# Altium schematic sheet MCU.SchDoc(MCU)
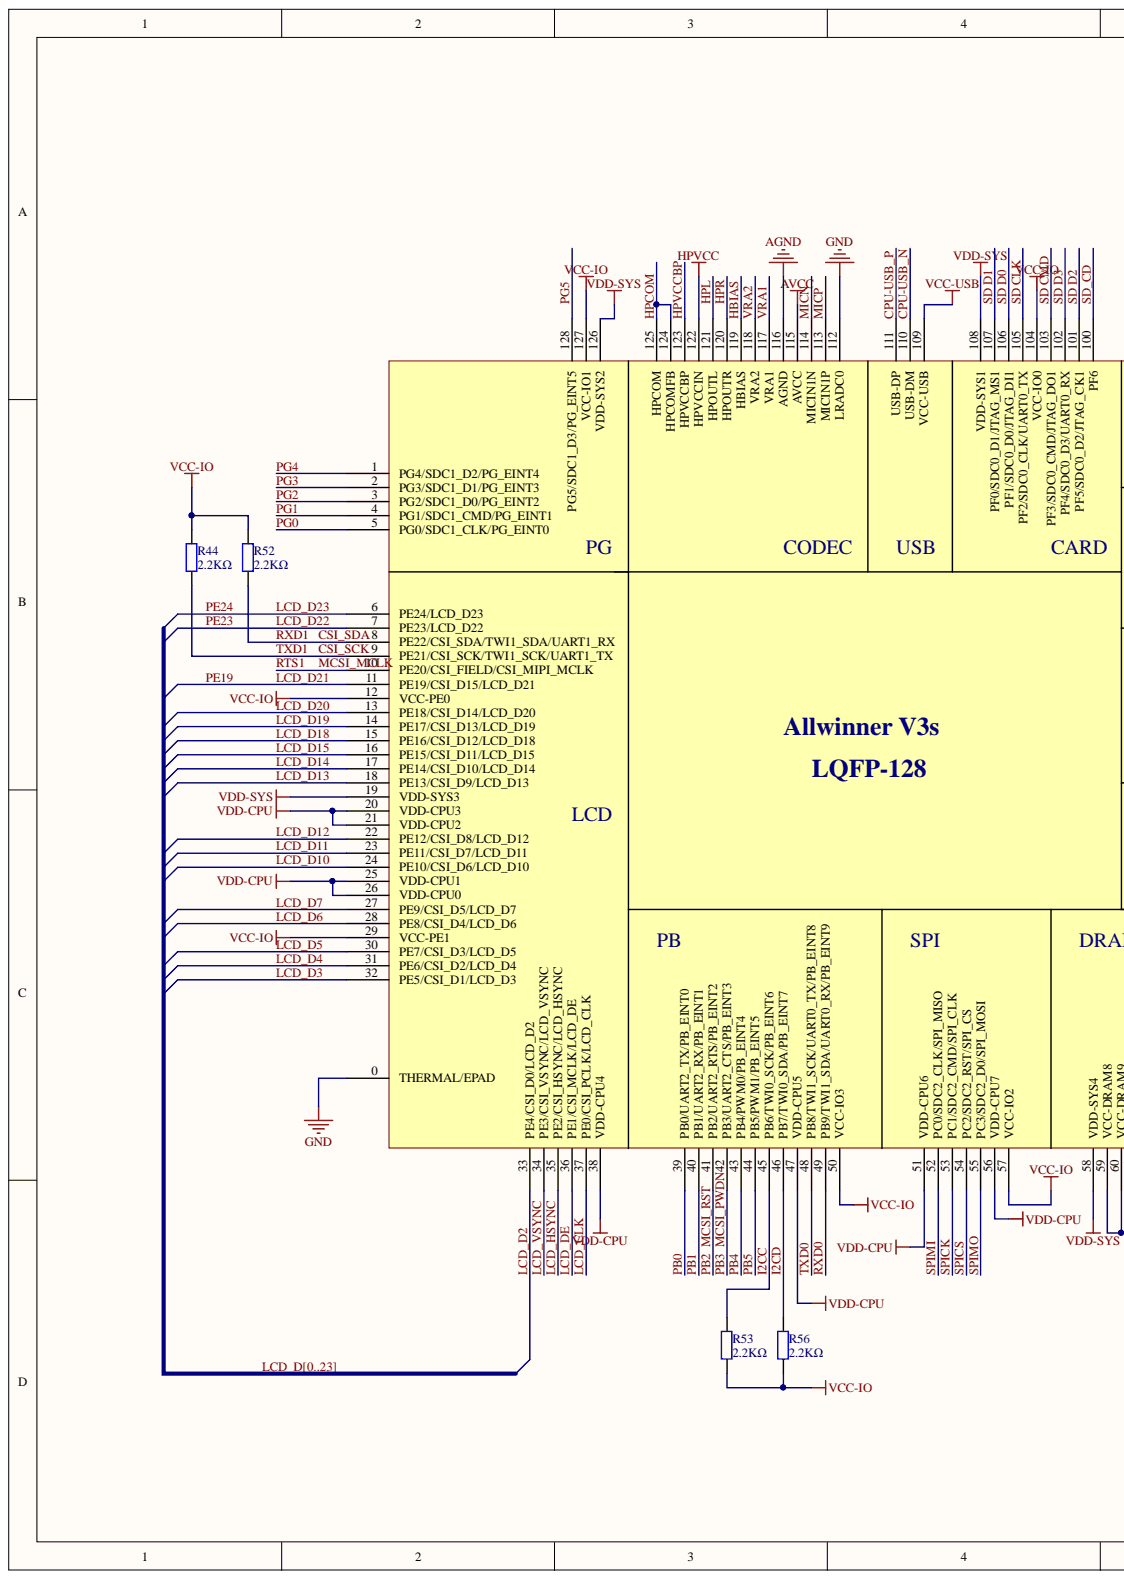
[diagram p0: MCU.SchDoc, left]
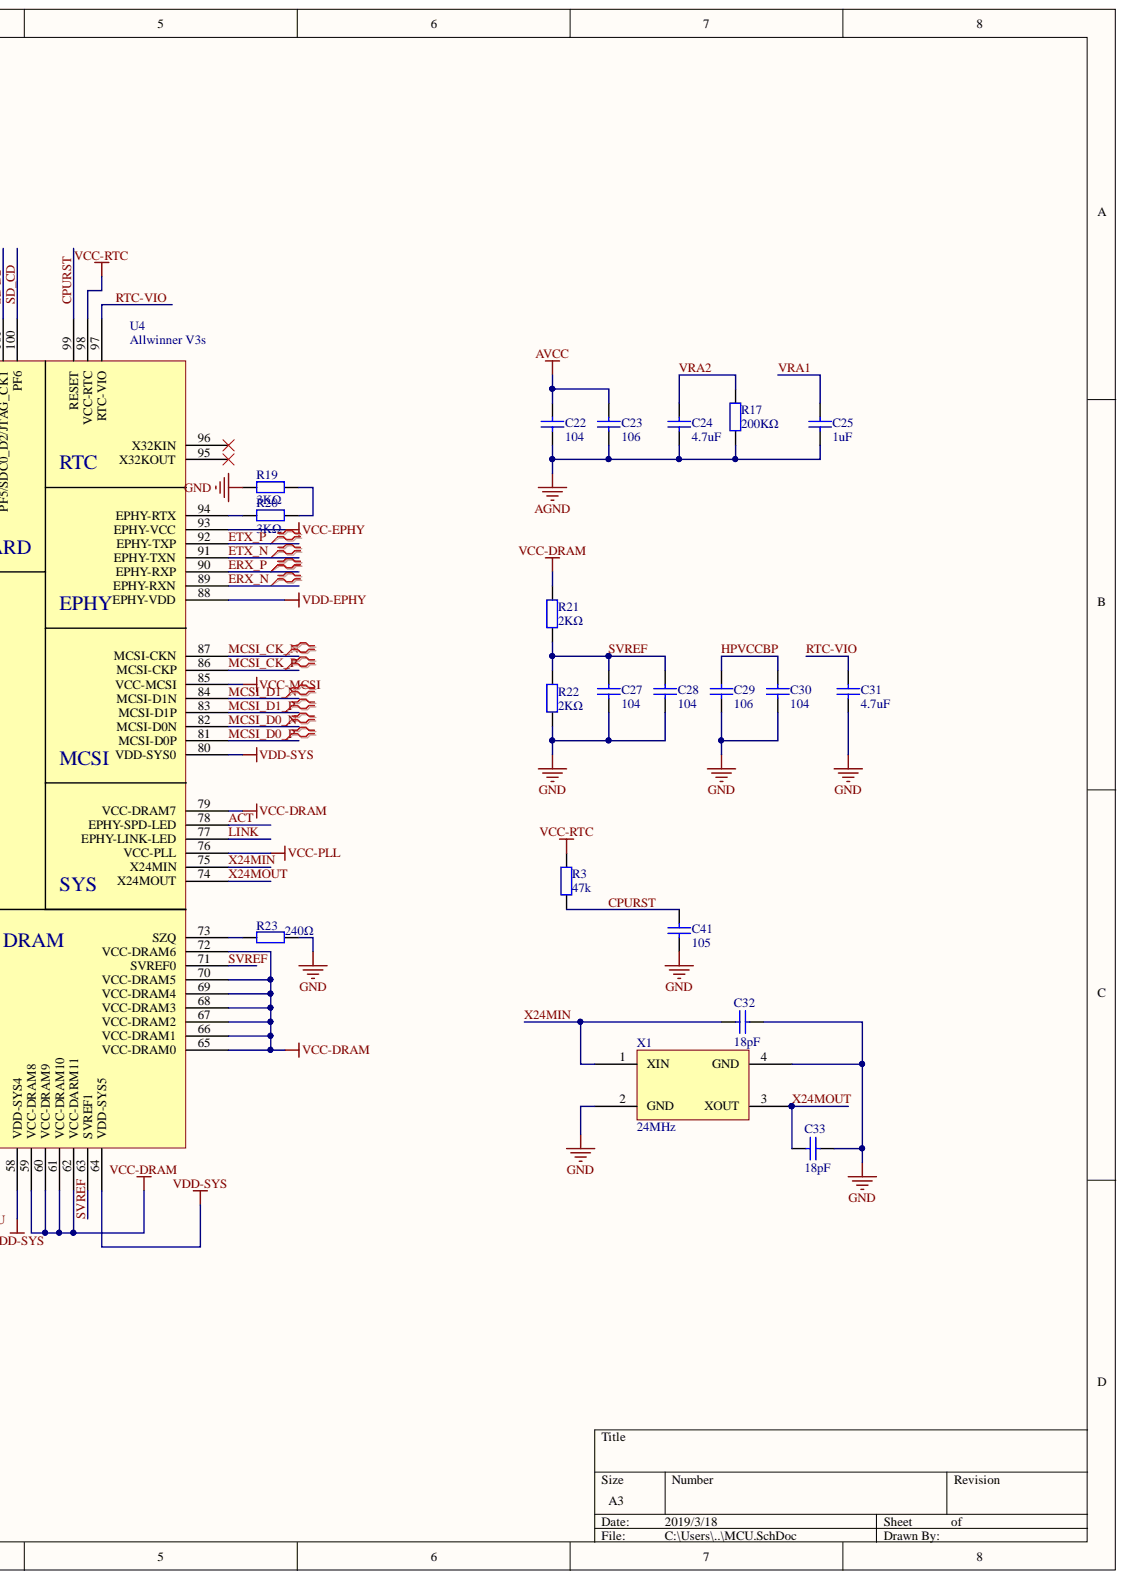
[diagram p1: MCU.SchDoc, right]

## Parts
C22  104  [0402_C]
C23  106  [0402_C]
C24  4.7uF  [0402_C]
C25  1uF  [0402_C]
C27  104  [0402_C]
C28  104  [0402_C]
C29  106  [0402_C]
C30  104  [0402_C]
C31  4.7uF  [0402_C]
C32  18pF  [0402_C]
C33  18pF  [0402_C]
C41  105  [0402_C]
R3  47k  [0402_R]
R17  200KΩ  [0402_R]
R19  3KΩ  [0603_R]
R20  3KΩ  [0603_R]
R21  2KΩ  [0402_R]
R22  2KΩ  [0402_R]
R23  240Ω  [0603_R]
R44  2.2KΩ  [0402_R]
R52  2.2KΩ  [0402_R]
R53  2.2KΩ  [0402_R]
R56  2.2KΩ  [0402_R]
U4  Allwinner V3s  [LQFP-128_THERMAL]
X1  24MHz  [SMD-3225_4P]

## Nets
AGND: C22.1 C23.1 C24.1 C25.1 R17.1 U4.116(AGND)
AVCC: C22.2 C23.2 U4.115(AVCC)
GND: C27.1 C28.1 C29.1 C30.1 C31.1 C32.2 C33.2 C41.1 R19.1 R22.1 R23.1 U4.0(THERMAL/EPAD) U4.112(LRADC0) X1.2(GND) X1.4(GND)
HPVCC: U4.122(HPVCCIN)
VCC-DRAM: R21.2 U4.59(VCC-DRAM8) U4.60(VCC-DRAM9) U4.61(VCC-DRAM10) U4.62(VCC-DARM11) U4.65(VCC-DRAM0) U4.66(VCC-DRAM1) U4.67(VCC-DRAM2) U4.68(VCC-DRAM3) U4.69(VCC-DRAM4) U4.70(VCC-DRAM5) U4.72(VCC-DRAM6) U4.79(VCC-DRAM7)
VCC-EPHY: U4.93(EPHY-VCC)
VCC-IO: R44.2 R52.2 R53.1 R56.1 U4.12(VCC-PE0) U4.29(VCC-PE1) U4.50(VCC-IO3) U4.57(VCC-IO2) U4.104(VCC-IO0) U4.127(VCC-IO1)
VCC-MCSI: U4.85(VCC-MCSI)
VCC-PLL: U4.76(VCC-PLL)
VCC-RTC: R3.2 U4.98(VCC-RTC)
VCC-USB: U4.109(VCC-USB)
VDD-CPU: U4.20(VDD-CPU3) U4.21(VDD-CPU2) U4.25(VDD-CPU1) U4.26(VDD-CPU0) U4.38(VDD-CPU4) U4.47(VDD-CPU5) U4.51(VDD-CPU6) U4.56(VDD-CPU7)
VDD-EPHY: U4.88(EPHY-VDD)
VDD-SYS: U4.19(VDD-SYS3) U4.58(VDD-SYS4) U4.64(VDD-SYS5) U4.80(VDD-SYS0) U4.108(VDD-SYS1) U4.126(VDD-SYS2)
ACT: U4.78(EPHY-SPD-LED)
CPU-USB_N: U4.110(USB-DM)
CPU-USB_P: U4.111(USB-DP)
CPURST: C41.2 R3.1 U4.99(RESET)
CSI_SCK: R44.1 U4.9(PE21/CSI_SCK/TWI1_SCK/UART1_TX)
CSI_SDA: R52.1 U4.8(PE22/CSI_SDA/TWI1_SDA/UART1_RX)
ERX_N: U4.89(EPHY-RXN)
ERX_P: U4.90(EPHY-RXP)
ETX_N: U4.91(EPHY-TXN)
ETX_P: U4.92(EPHY-TXP)
HBIAS: U4.119(HBIAS)
HPCOM: U4.124(HPCOMFB) U4.125(HPCOM)
HPL: U4.121(HPOUTL)
HPR: U4.120(HPOUTR)
HPVCCBP: C29.2 C30.2 U4.123(HPVCCBP)
I2CC: R53.2 U4.45(PB6/TWI0_SCK/PB_EINT6)
I2CD: R56.2 U4.46(PB7/TWI0_SDA/PB_EINT7)
LCD_CLK: U4.37(PE0/CSI_PCLK/LCD_CLK)
LCD_D2: U4.33(PE4/CSI_D0/LCD_D2)  [also labelled LCD_D]
LCD_D3: U4.32(PE5/CSI_D1/LCD_D3)  [also labelled LCD_D]
LCD_D4: U4.31(PE6/CSI_D2/LCD_D4)  [also labelled LCD_D]
LCD_D5: U4.30(PE7/CSI_D3/LCD_D5)  [also labelled LCD_D]
LCD_D6: U4.28(PE8/CSI_D4/LCD_D6)  [also labelled LCD_D]
LCD_D7: U4.27(PE9/CSI_D5/LCD_D7)  [also labelled LCD_D]
LCD_D10: U4.24(PE10/CSI_D6/LCD_D10)  [also labelled LCD_D]
LCD_D11: U4.23(PE11/CSI_D7/LCD_D11)  [also labelled LCD_D]
LCD_D12: U4.22(PE12/CSI_D8/LCD_D12)  [also labelled LCD_D]
LCD_D13: U4.18(PE13/CSI_D9/LCD_D13)  [also labelled LCD_D]
LCD_D14: U4.17(PE14/CSI_D10/LCD_D14)  [also labelled LCD_D]
LCD_D15: U4.16(PE15/CSI_D11/LCD_D15)  [also labelled LCD_D]
LCD_D18: U4.15(PE16/CSI_D12/LCD_D18)  [also labelled LCD_D]
LCD_D19: U4.14(PE17/CSI_D13/LCD_D19)  [also labelled LCD_D]
LCD_D20: U4.13(PE18/CSI_D14/LCD_D20)  [also labelled LCD_D]
LCD_D21: U4.11(PE19/CSI_D15/LCD_D21)  [also labelled LCD_D]
LCD_D22: U4.7(PE23/LCD_D22)  [also labelled LCD_D]
LCD_D23: U4.6(PE24/LCD_D23)  [also labelled LCD_D]
LCD_DE: U4.36(PE1/CSI_MCLK/LCD_DE)
LCD_HSYNC: U4.35(PE2/CSI_HSYNC/LCD_HSYNC)
LCD_VSYNC: U4.34(PE3/CSI_VSYNC/LCD_VSYNC)
LINK: U4.77(EPHY-LINK-LED)
MCSI_CK_N: U4.87(MCSI-CKN)
MCSI_CK_P: U4.86(MCSI-CKP)
MCSI_D0_N: U4.82(MCSI-D0N)
MCSI_D0_P: U4.81(MCSI-D0P)
MCSI_D1_N: U4.84(MCSI-D1N)
MCSI_D1_P: U4.83(MCSI-D1P)
MCSI_MCLK: U4.10(PE20/CSI_FIELD/CSI_MIPI_MCLK)
MCSI_PWDN: U4.42(PB3/UART2_CTS/PB_EINT3)
MCSI_RST: U4.41(PB2/UART2_RTS/PB_EINT2)
MICN: U4.114(MICIN1N)
MICP: U4.113(MICIN1P)
PB0: U4.39(PB0/UART2_TX/PB_EINT0)
PB1: U4.40(PB1/UART2_RX/PB_EINT1)
PB4: U4.43(PB4/PWM0/PB_EINT4)
PB5: U4.44(PB5/PWM1/PB_EINT5)
PG0: U4.5(PG0/SDC1_CLK/PG_EINT0)
PG1: U4.4(PG1/SDC1_CMD/PG_EINT1)
PG2: U4.3(PG2/SDC1_D0/PG_EINT2)
PG3: U4.2(PG3/SDC1_D1/PG_EINT3)
PG4: U4.1(PG4/SDC1_D2/PG_EINT4)
PG5: U4.128(PG5/SDC1_D3/PG_EINT5)
RTC-VIO: C31.2 U4.97(RTC-VIO)
RXD0: U4.49(PB9/TWI1_SDA/UART0_RX/PB_EINT9)
SD CLK: U4.105(PF2/SDC0_CLK/UART0_TX)
SD CMD: U4.103(PF3/SDC0_CMD/JTAG_DO1)
SD D0: U4.106(PF1/SDC0_D0/JTAG_DI1)
SD D1: U4.107(PF0/SDC0_D1/JTAG_MS1)
SD D2: U4.101(PF5/SDC0_D2/JTAG_CK1)
SD D3: U4.102(PF4/SDC0_D3/UART0_RX)
SD_CD: U4.100(PF6)
SPICK: U4.53(PC1/SDC2_CMD/SPI_CLK)
SPICS: U4.54(PC2/SDC2_RST/SPI_CS)
SPIMI: U4.52(PC0/SDC2_CLK/SPI_MISO)
SPIMO: U4.55(PC3/SDC2_D0/SPI_MOSI)
SVREF: C27.2 C28.2 R21.1 R22.2 U4.63(SVREF1) U4.71(SVREF0)
TXD0: U4.48(PB8/TWI1_SCK/UART0_TX/PB_EINT8)
VRA1: C25.2 U4.117(VRA1)
VRA2: C24.2 R17.2 U4.118(VRA2)
X24MIN: C32.1 U4.75(X24MIN) X1.1(XIN)
X24MOUT: C33.1 U4.74(X24MOUT) X1.3(XOUT)
NetR19_2: R19.2 R20.2
NetR20_1: R20.1 U4.94(EPHY-RTX)
NetR23_2: R23.2 U4.73(SZQ)
LCD_D0:  [also labelled LCD_D]
LCD_D1:  [also labelled LCD_D]
LCD_D8:  [also labelled LCD_D]
LCD_D9:  [also labelled LCD_D]
LCD_D16:  [also labelled LCD_D]
LCD_D17:  [also labelled LCD_D]

Not connected: U4 95(X32KOUT) 96(X32KIN)

## Library data of the parts
C22 C27 C28 C30 = 0603 100nF (104) 10% 50V: 贴片电容; SuppliersPartNumber: C14663; Supplier: LC; Package: 0603; Library Name: Cap.SchLib; ComponentLink1URL: http://www.szlcsc.com/product/details_15331.html; ComponentLink1Description: 供应商链接; Component Kind: Standard
C23 C29 = 0603 10uF (106) 10% 10V: 贴片电容; SuppliersPartNumber: C19702; Supplier: LC; Package: 0603; Library Name: Cap.SchLib; ComponentLink1URL: http://www.szlcsc.com/product/details_20411.html; ComponentLink1Description: 供应商链接; Component Kind: Standard
C24 C31 = 0603 4.7uF (475) 10% 16V: 贴片电容; SuppliersPartNumber: C19666; Supplier: LC; Package: 0603; Library Name: Cap.SchLib; ComponentLink1URL: http://www.szlcsc.com/product/details_20375.html; ComponentLink1Description: 供应商链接; Component Kind: Standard
C25 C41 = 0603 1uF (105) 10% 50V: 贴片电容; SuppliersPartNumber: C15849; Supplier: LC; Package: 0603; ComponentLink1URL: http://www.szlcsc.com/product/details_16531.html; ComponentLink1Description: 供应商链接; Component Kind: Standard
  C25: Library Name: Cap.SchLib
  C41: Library Name: Miscellaneous Devices LC.IntLib
C32 C33 = 0603 18pF (180) 5% 50V: 贴片电容; SuppliersPartNumber: C1647; Supplier: LC; Package: 0603; Library Name: Cap.SchLib; ComponentLink1URL: http://www.szlcsc.com/product/details_1999.html; ComponentLink1Description: 供应商链接; Component Kind: Standard
R3 = 0402 47KΩ (4702) 1%: 贴片电阻; SuppliersPartNumber: C25792; Supplier: LC; Package: 0402; Library Name: RES.SchLib; ComponentLink1URL: http://www.szlcsc.com/product/details_26535.html; ComponentLink1Description: 供应商链接; Component Kind: Standard
R17 = 0603 200KΩ (2003) 1%: 贴片电阻; SuppliersPartNumber: C25811; Supplier: LC; Package: 0603; Library Name: RES.SchLib; ComponentLink1URL: http://www.szlcsc.com/product/details_26554.html; ComponentLink1Description: 供应商链接; Component Kind: Standard
R19 R20 = 0603 3KΩ (3001) 1%: 贴片电阻; SuppliersPartNumber: C4211; Supplier: LC; Package: 0603; Library Name: RES.SchLib; ComponentLink1URL: http://www.szlcsc.com/product/details_4618.html; ComponentLink1Description: 供应商链接; Component Kind: Standard
R21 R22 = 0603 2KΩ (2001) 1%: 贴片电阻; SuppliersPartNumber: C22975; Supplier: LC; Package: 0603; Library Name: RES.SchLib; ComponentLink1URL: http://www.szlcsc.com/product/details_23702.html; ComponentLink1Description: 供应商链接; Component Kind: Standard
R23 = 0603 240Ω (2400) 1%: 贴片电阻; SuppliersPartNumber: C23350; Supplier: LC; Package: 0603; Library Name: RES.SchLib; ComponentLink1URL: http://www.szlcsc.com/product/details_24083.html; ComponentLink1Description: 供应商链接; Component Kind: Standard
R44 R52 R53 R56 = 0402 2.2KΩ (2201) 1%: 贴片电阻; SuppliersPartNumber: C25879; Supplier: LC; Package: 0402; Library Name: Miscellaneous Devices LC.IntLib; ComponentLink1URL: http://www.szlcsc.com/product/details_26622.html; ComponentLink1Description: 供应商链接; Component Kind: Standard
U4 = V3S: Allwinner V3s SoC; Component Kind: Standard; Library Name: Schlib_FFS.SchLib
X1 = XTAL-24M: SMD Crystal 24MHz; LC: C15643; Component Kind: Standard; Library Name: Schlib_FFS.SchLib
